# Altium schematic sheet TipWiring.SchDoc
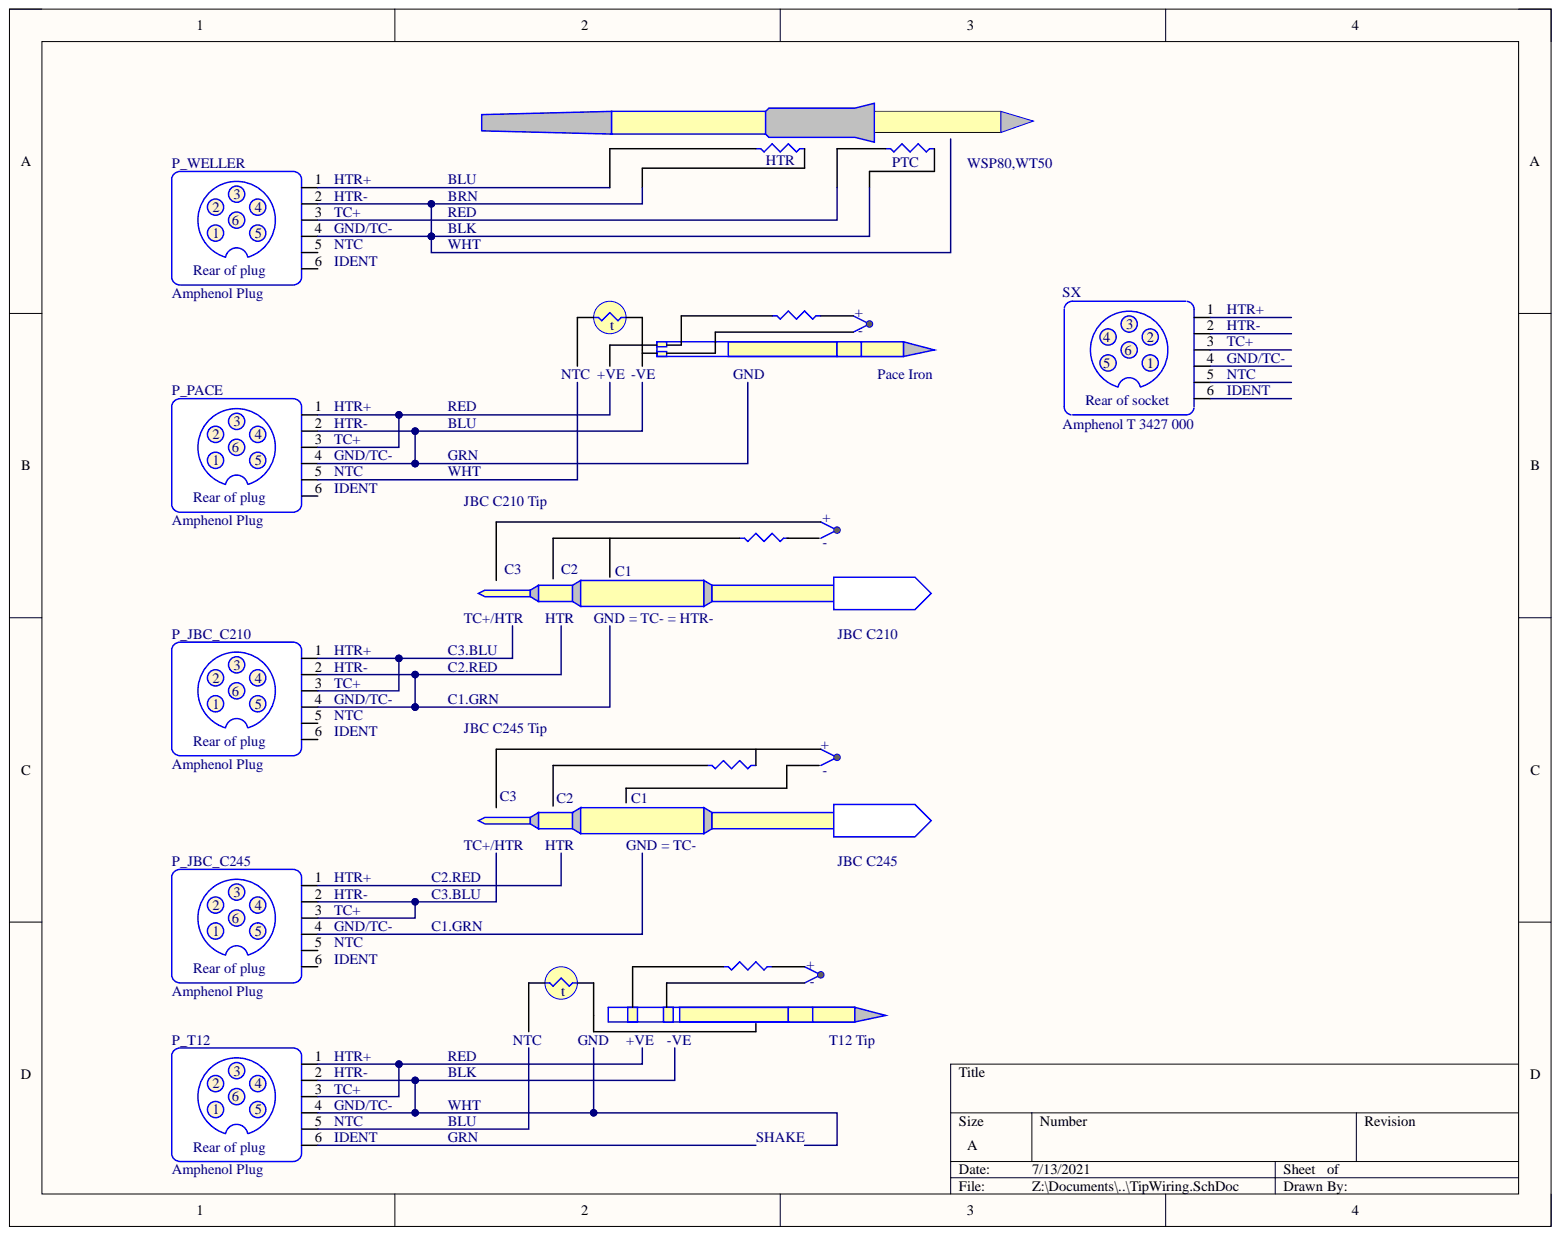
[diagram p0: TipWiring.SchDoc]

## Parts
JBC_C210_Tip  JBC C210  (mechanical)
JBC_C245_Tip  JBC C245  (mechanical)
P_JBC_C210  Amphenol Plug  (mechanical)
P_JBC_C245  Amphenol Plug  (mechanical)
P_PACE  Amphenol Plug  (mechanical)
P_T12  Amphenol Plug  (mechanical)
P_WELLER  Amphenol Plug  (mechanical)
Pace_Iron  Pace  (mechanical)
SX  Amphenol T 3427 000  (mechanical)
T12_Tip  T12 Tip  (mechanical)
WSP80,WT50  WELLER WSP80  (value not drawn)  (mechanical)

## Nets
NetP_JBC_C210_1: P_JBC_C210.1 P_JBC_C210.3
NetP_JBC_C210_2: P_JBC_C210.2 P_JBC_C210.4
NetP_JBC_C245_2: P_JBC_C245.2 P_JBC_C245.3
NetP_PACE_1: P_PACE.1 P_PACE.3
NetP_PACE_2: P_PACE.2 P_PACE.4
NetP_T12_1: P_T12.1 P_T12.3
NetP_T12_2: P_T12.2 P_T12.4
NetP_WELLER_2: P_WELLER.2 P_WELLER.4

Not connected: P_JBC_C210 5 6; P_JBC_C245 1 4 5 6; P_PACE 5 6; P_T12 5 6; P_WELLER 1 3 5 6; SX 1 2 3 4 5 6

## Library data of the parts
P_JBC_C210 P_JBC_C245 P_PACE P_T12 P_WELLER = Amphenol Plug: Circular Connector, C091B Series, Panel Mount Receptacle, 6 Contacts, Solder Socket, Metal Body; Library Name: MySchLib.schlib
SX = Amphenol T 3427 000: Circular Connector, C091B Series, Panel Mount Receptacle, 6 Contacts, Solder Socket, Metal Body; Library Name: MySchLib.schlib
JBC_C210_Tip = JBC C210: Library Name: MySchLib.schlib
JBC_C245_Tip = JBC C245: Library Name: MySchLib.schlib
Pace_Iron = Pace: Library Name: MySchLib.schlib
T12_Tip = T12 Tip: Library Name: MySchLib.schlib
WSP80,WT50 = WELLER WSP80: Library Name: MySchLib.schlib
